# Altium schematic sheet Sheet1.SchDoc
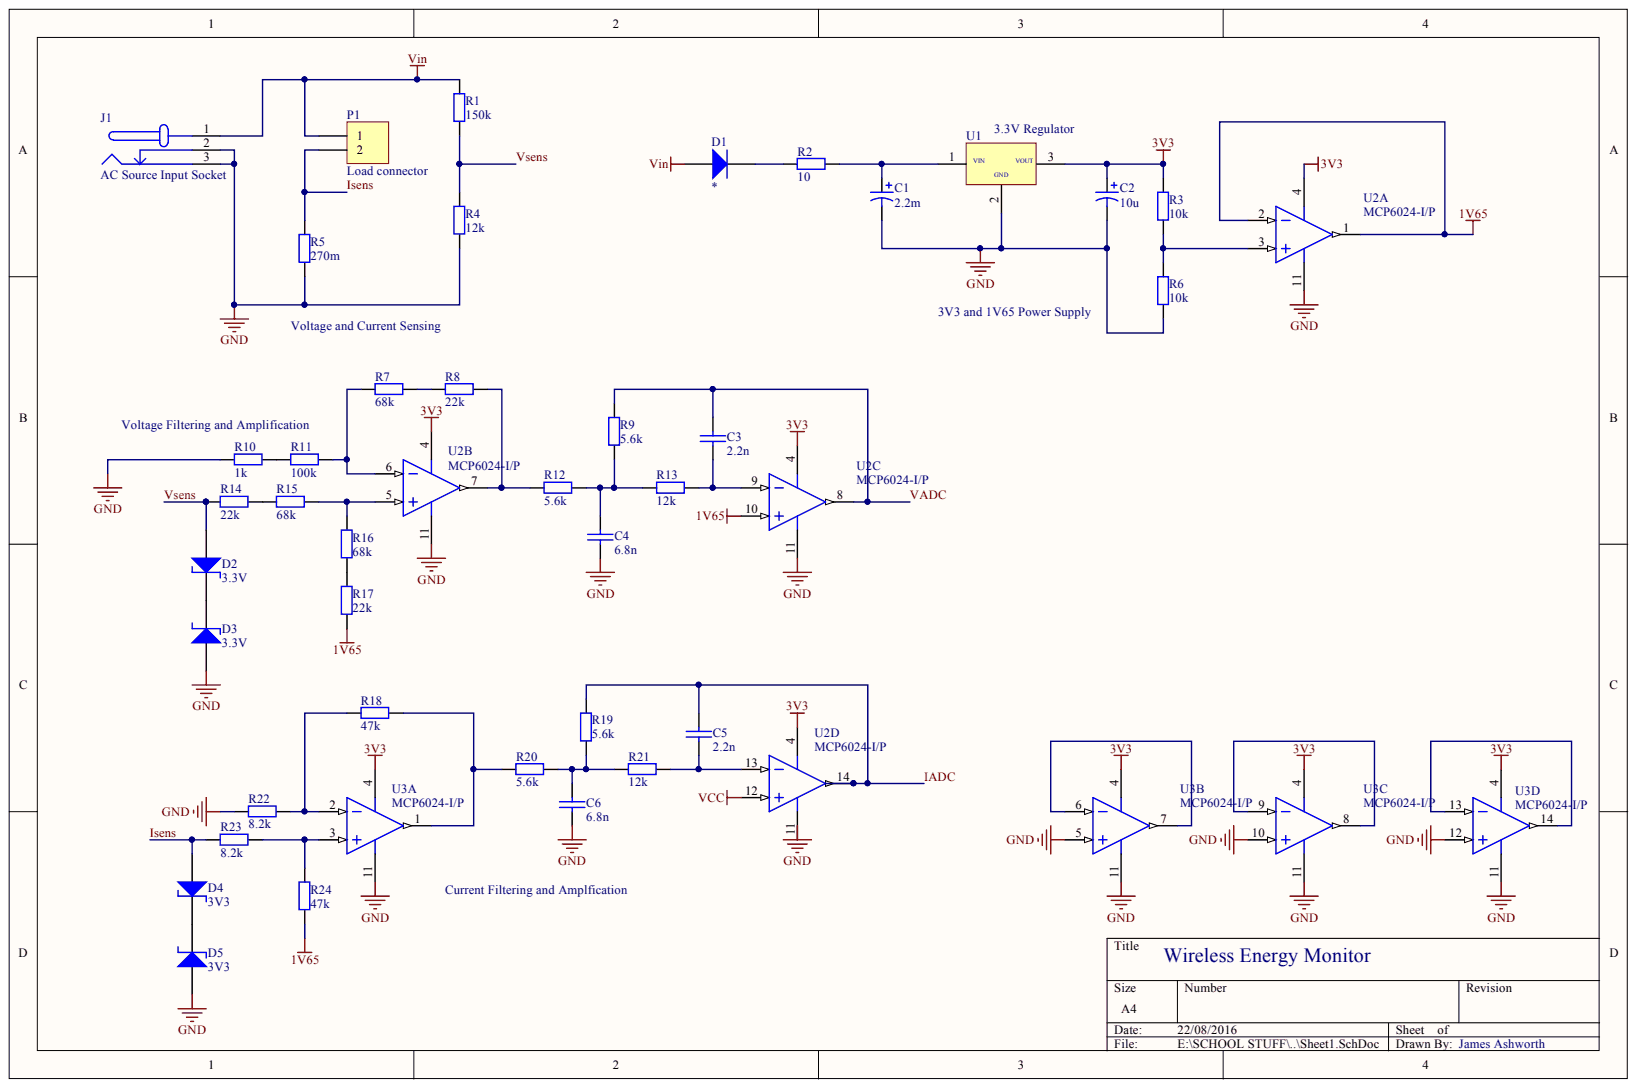
[diagram p0: Sheet1.SchDoc]

## Parts
C1  2.2m  [CAP-RAD-7.5mm Pitch]
C2  10u  [CAP-RAD-3mm Pitch]
C3  2.2n  [FILM CAP-0.2]
C4  6.8n  [FILM CAP-0.2]
C5  2.2n  [FILM CAP-0.2]
C6  6.8n  [FILM CAP-0.2]
D1  (no value)  [AXIAL-DIODE-0.4]
D2  3.3V  [AXIAL-DIODE-0.4]
D3  3.3V  [AXIAL-DIODE-0.4]
D4  3V3  [AXIAL-DIODE-0.4]
D5  3V3  [AXIAL-DIODE-0.4]
J1  AC Source Input Socket  [AC-Socket]
P1  Load connector  [Dual Banana Plug]
R1  150k  [AXIAL-0.4]
R2  10  [AXIAL-0.4]
R3  10k  [AXIAL-0.4]
R4  12k  [AXIAL-0.4]
R5  270m  [0805 RES]
R6  10k  [AXIAL-0.4]
R7  68k  [AXIAL-0.4]
R8  22k  [AXIAL-0.4]
R9  5.6k  [0805 RES]
R10  1k  [AXIAL-0.4]
R11  100k  [AXIAL-0.4]
R12  5.6k  [AXIAL-0.4]
R13  12k  [0805 RES]
R14  22k  [AXIAL-0.4]
R15  68k  [AXIAL-0.4]
R16  68k  [AXIAL-0.4]
R17  22k  [AXIAL-0.4]
R18  47k  [AXIAL-0.4]
R19  5.6k  [AXIAL-0.4]
R20  5.6k  [AXIAL-0.4]
R21  12k  [AXIAL-0.4]
R22  8.2k  [AXIAL-0.4]
R23  8.2k  [AXIAL-0.4]
R24  47k  [AXIAL-0.4]
U1  3.3V Regulator  [TO-92]
U2  MCP6024-I/P  [DIP-14]  (parts A,B,C,D)
U3  MCP6024-I/P  [DIP-14]  (parts A,B,C,D)

## Nets
1V65: R17.1 R24.1 U2.1 U2.2 U2.10
3V3: C2.1 R3.2 U1.3 U2.4 U3.4
GND: C1.2 C2.2 C4.2 C6.2 D3.1 D5.1 J1.2 J1.3 R4.1 R5.1 R6.1 R10.1 R22.1 U1.2 U2.11 U3.5 U3.10 U3.11 U3.12
VCC: U2.12
VIN: D1.1 J1.1 P1.1 R1.2
IADC: C5.1 R19.1 U2.14
ISENS: D4.1 P1.2 R5.2 R23.1
VADC: C3.1 R9.2 U2.8
VSENS: D2.1 R1.1 R4.2 R14.1
NetC1_1: C1.1 R2.2 U1.1
NetC3_2: C3.2 R13.1 U2.9
NetC4_1: C4.1 R9.1 R12.2 R13.2
NetC5_2: C5.2 R21.1 U2.13
NetC6_1: C6.1 R19.2 R20.1 R21.2
NetD1_2: D1.2 R2.1
NetD2_2: D2.2 D3.2
NetD4_2: D4.2 D5.2
NetR3_1: R3.1 R6.2 U2.3
NetR7_1: R7.1 R11.2 U2.6
NetR7_2: R7.2 R8.1
NetR8_2: R8.2 R12.1 U2.7
NetR10_2: R10.2 R11.1
NetR14_2: R14.2 R15.1
NetR15_2: R15.2 R16.2 U2.5
NetR16_1: R16.1 R17.2
NetR18_1: R18.1 R20.2 U3.1
NetR18_2: R18.2 R22.2 U3.2
NetR23_2: R23.2 R24.2 U3.3
NetU3_6: U3.6 U3.7
NetU3_8: U3.8 U3.9
NetU3_13: U3.13 U3.14

## Library data of the parts
C1 = Cap Pol (220uF-470uF): Polarized Capacitor (Axial); Component Kind: Standard; LatestRevisionDate: 17-Jul-2002; LatestRevisionNote: Re-released for DXP Platform.; Library Name: EE209_SCHLibrary.SchLib; PackageDescription: Polarized Capacitor; 2 Leads; PackageReference: POLAR0.8; Published: 8-Jun-2000; Publisher: Altium Limited
C2 = Cap Pol (22uF-100uF): Polarized Capacitor (Axial); Component Kind: Standard; LatestRevisionDate: 17-Jul-2002; LatestRevisionNote: Re-released for DXP Platform.; Library Name: EE209_SCHLibrary.SchLib; PackageDescription: Polarized Capacitor; 2 Leads; PackageReference: POLAR0.8; Published: 8-Jun-2000; Publisher: Altium Limited
C3-C6 = CAP: Capacitor Non Polarised Through Hole; Component Kind: Standard; Library Name: EE209_SCHLibrary.SchLib
D1 = Diode: Manufacturer: Fairchild Semiconductor; Class I: Discrete; Class II: Diode - Switching; Revision 1: 11-July-2000: SIM Model Added.; Revision 2: July-2002: Re-released for DXP Platform.; Component Kind: Standard; Datasheet: Latest Revision: 1997; Library Name: EE209_SCHLibrary.SchLib; Package Reference: DO-35; Published: 8-Jun-2000; Publisher: Altium Limited
D2-D5 = Zener: Zener Diode; Component Kind: Standard; Library Name: EE209_SCHLibrary.SchLib
J1 = AC Input: Component Kind: Standard; Description: AC Source Input Socket; Library Name: EE209_SCHLibrary.SchLib; Value: socket
P1 = Dual Banana Plugs: M6 Holes; Component Kind: Standard; LatestRevisionDate: 17-Jul-2002; LatestRevisionNote: Re-released for DXP Platform.; Library Name: EE209_SCHLibrary.SchLib; Publisher: Altium Limited
R1-R24 = Res: Through Hole Resistor; Component Kind: Standard; LatestRevisionDate: 17-Jul-2002; LatestRevisionNote: Re-released for DXP Platform.; Library Name: EE209_SCHLibrary.SchLib; PackageReference: AXIAL-0.4; Published: 8-Jun-2000; Publisher: Altium Limited
U1 = LP2950-33: Component Kind: Standard; Library Name: EE209_SCHLibrary.SchLib
U2 U3 = MCP6024-I/P: Rail-to-Rail Input/Output, 10 MHz Operational Amplifier, 14-Pin PDIP, Industrial Temperature; Component Kind: Standard; ComponentLink1Description: Datasheet; ComponentLink1URL: http://ww1.microchip.com/downloads/en/DeviceDoc/21685d.pdf; ComponentLink2Description: Packaging Specification; ComponentLink2URL: http://www.microchip.com/stellent/groups/techpub_sg/documents/packagingspec/en012702.pdf; ComponentLink3Description: Microchip.com Home; ComponentLink3URL: http://www.microchip.com/; DatasheetVersion: revD, Feb-2009; FirstPublished: 29-Jan-2009; LatestRevisionDate: 02-Dec-2009; LatestRevisionNote: Updated to datasheet revision D, Feb-2009; Library Name: EE209_SCHLibrary.SchLib; PackageDescription: 14-Lead Plastic Dual In-Line (P) - 300 mil Body [PDIP]; PackageReference: PDIP300-P14; PackageVersion: revBB, Aug-2009; Publisher: Altium Limited
